# Altium schematic sheet Z80 Card for Commodore C64.SchDoc("Z80 Card for Commodore C64")
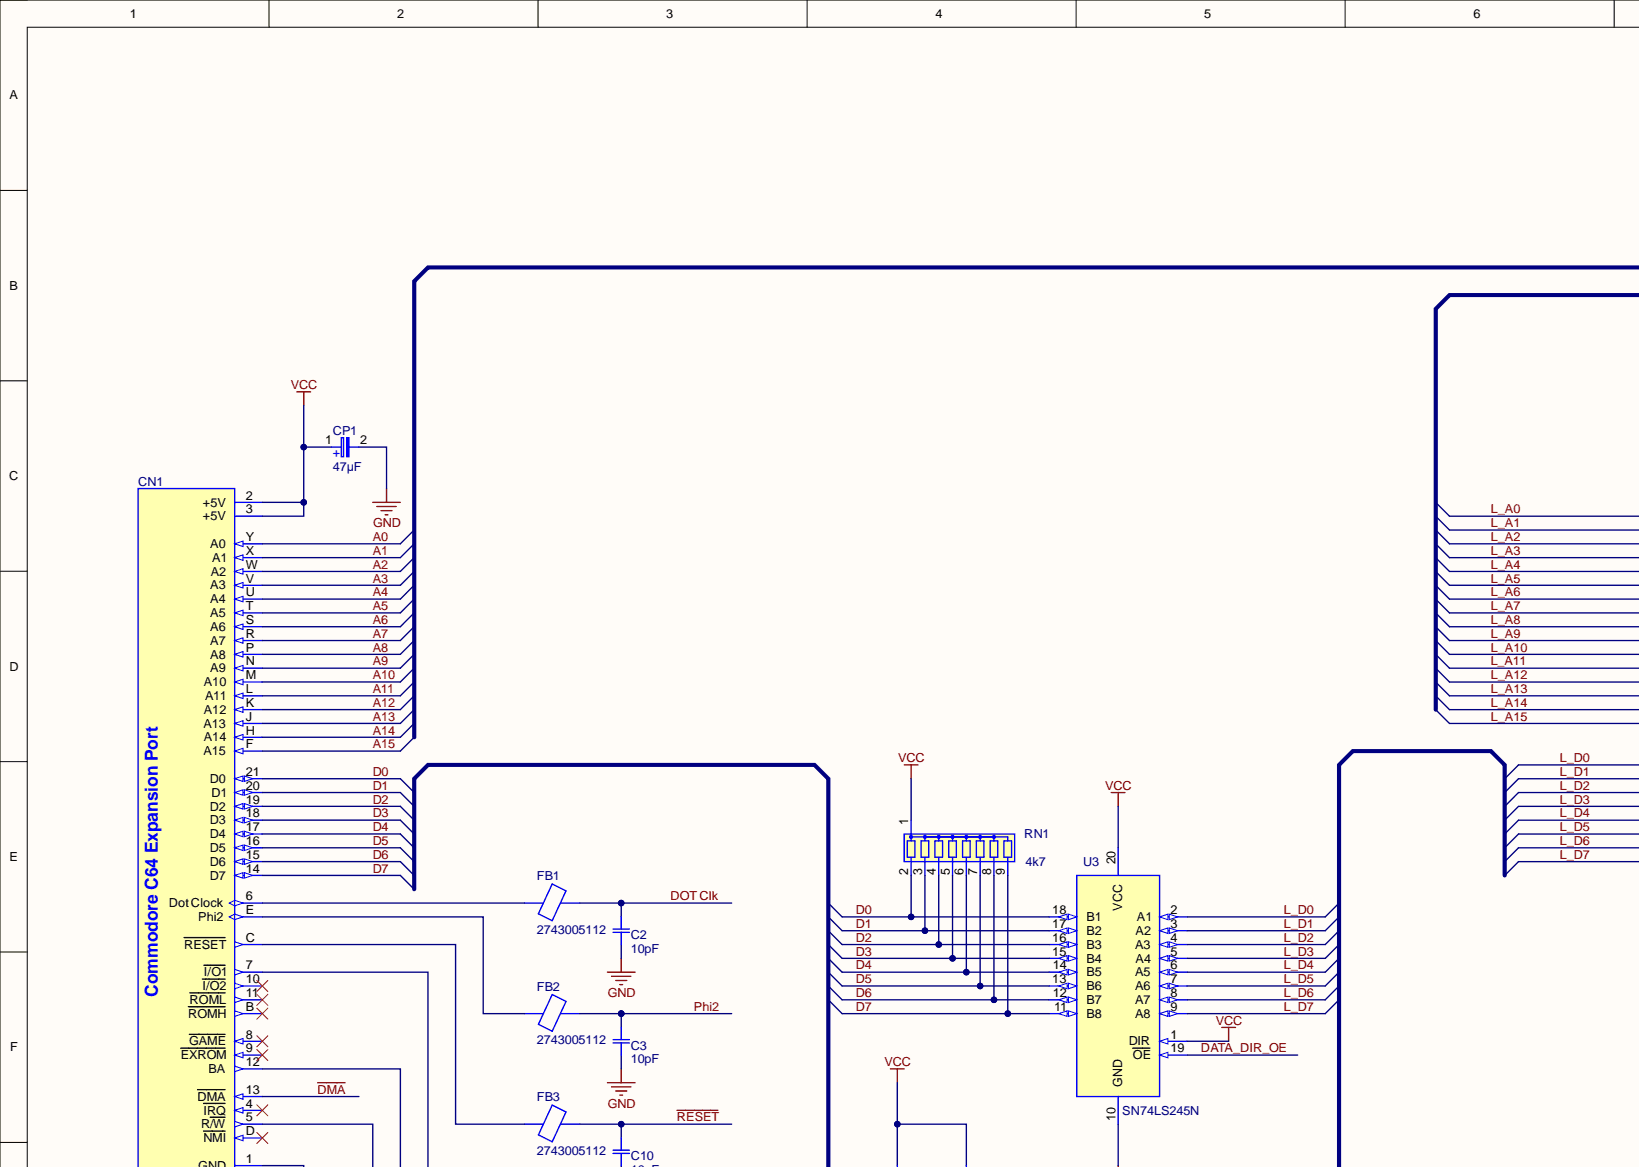
[diagram p0: Z80 Card for Commodore C64.SchDoc, top left]
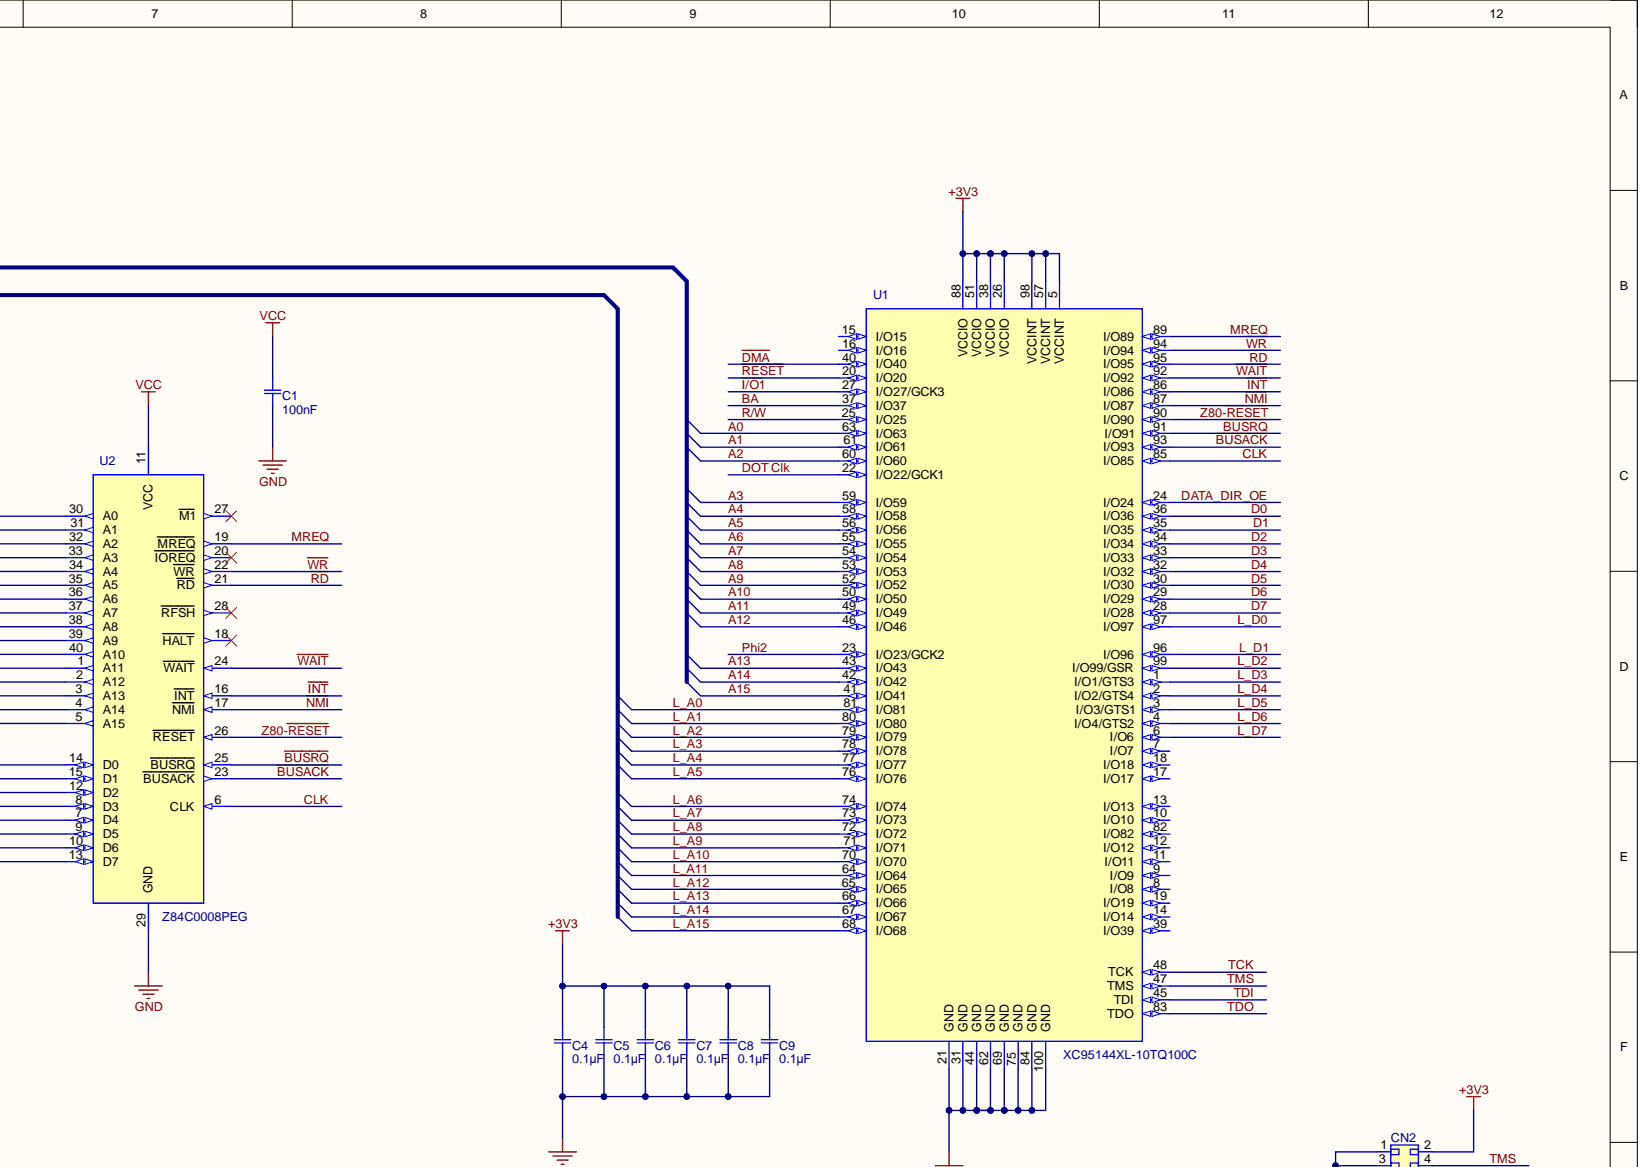
[diagram p1: Z80 Card for Commodore C64.SchDoc, top right]
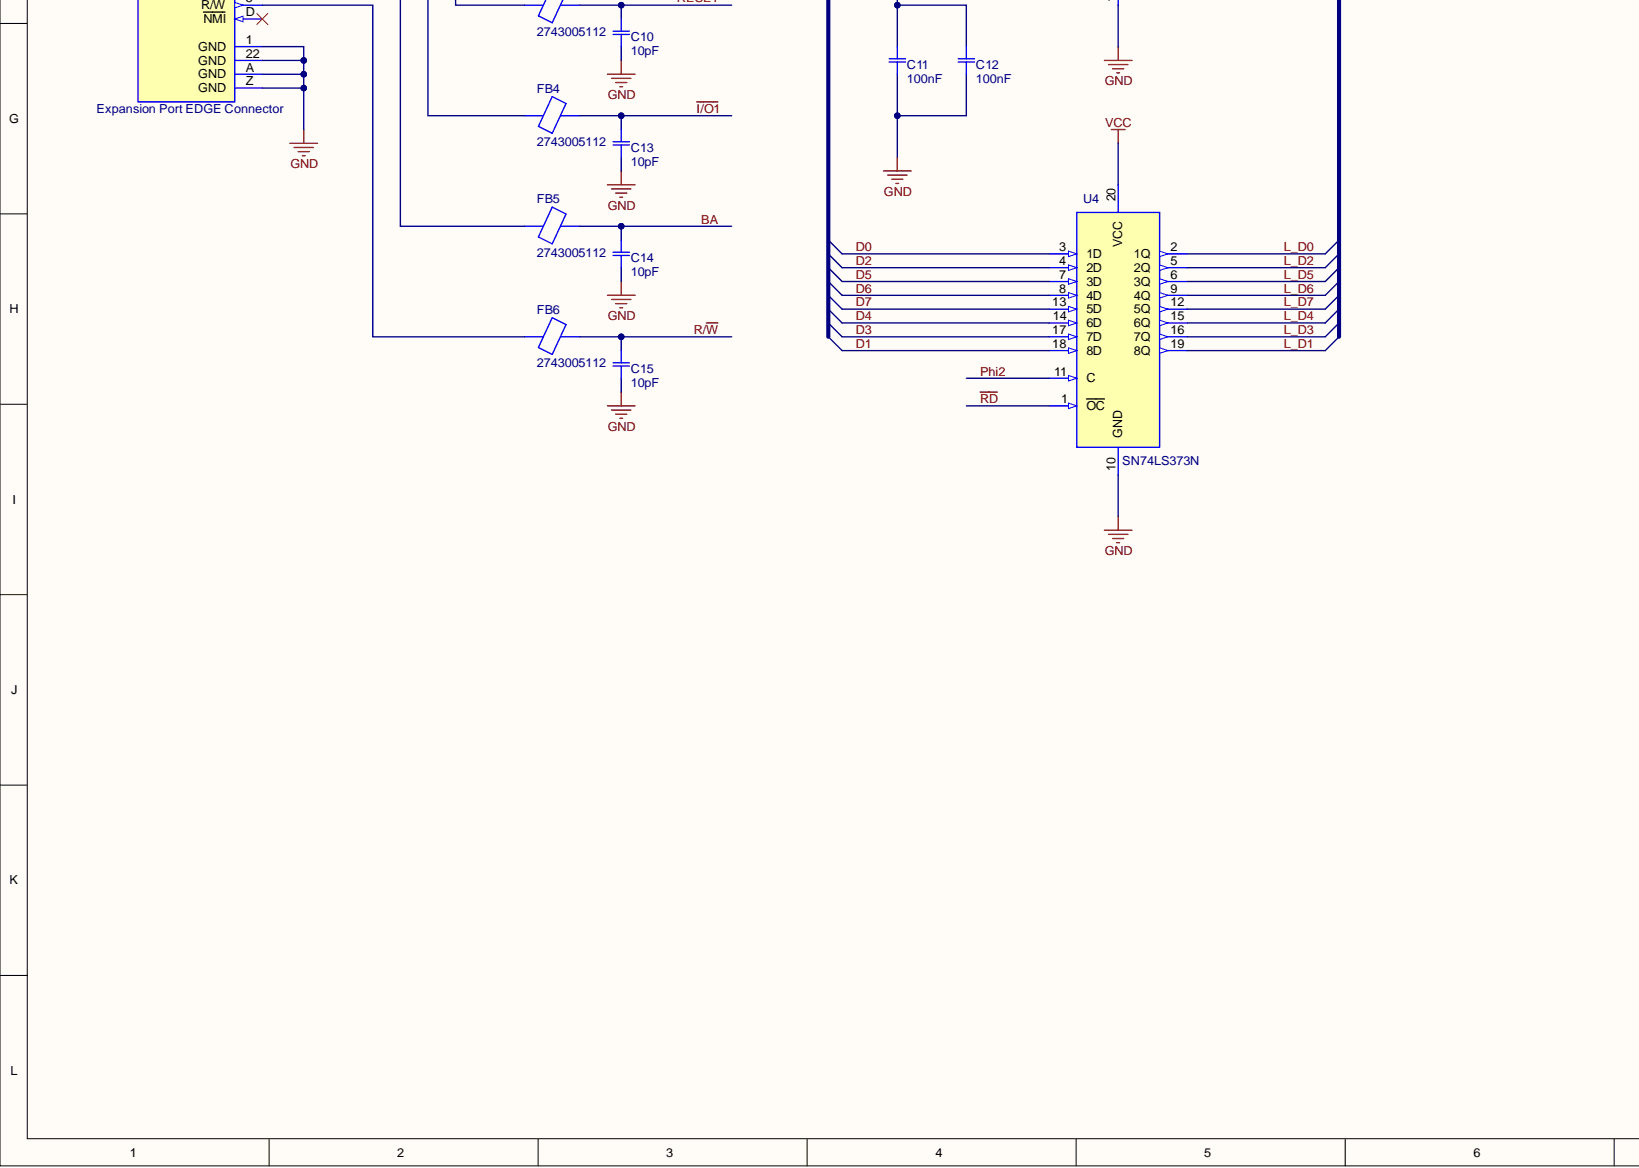
[diagram p2: Z80 Card for Commodore C64.SchDoc, bottom left]
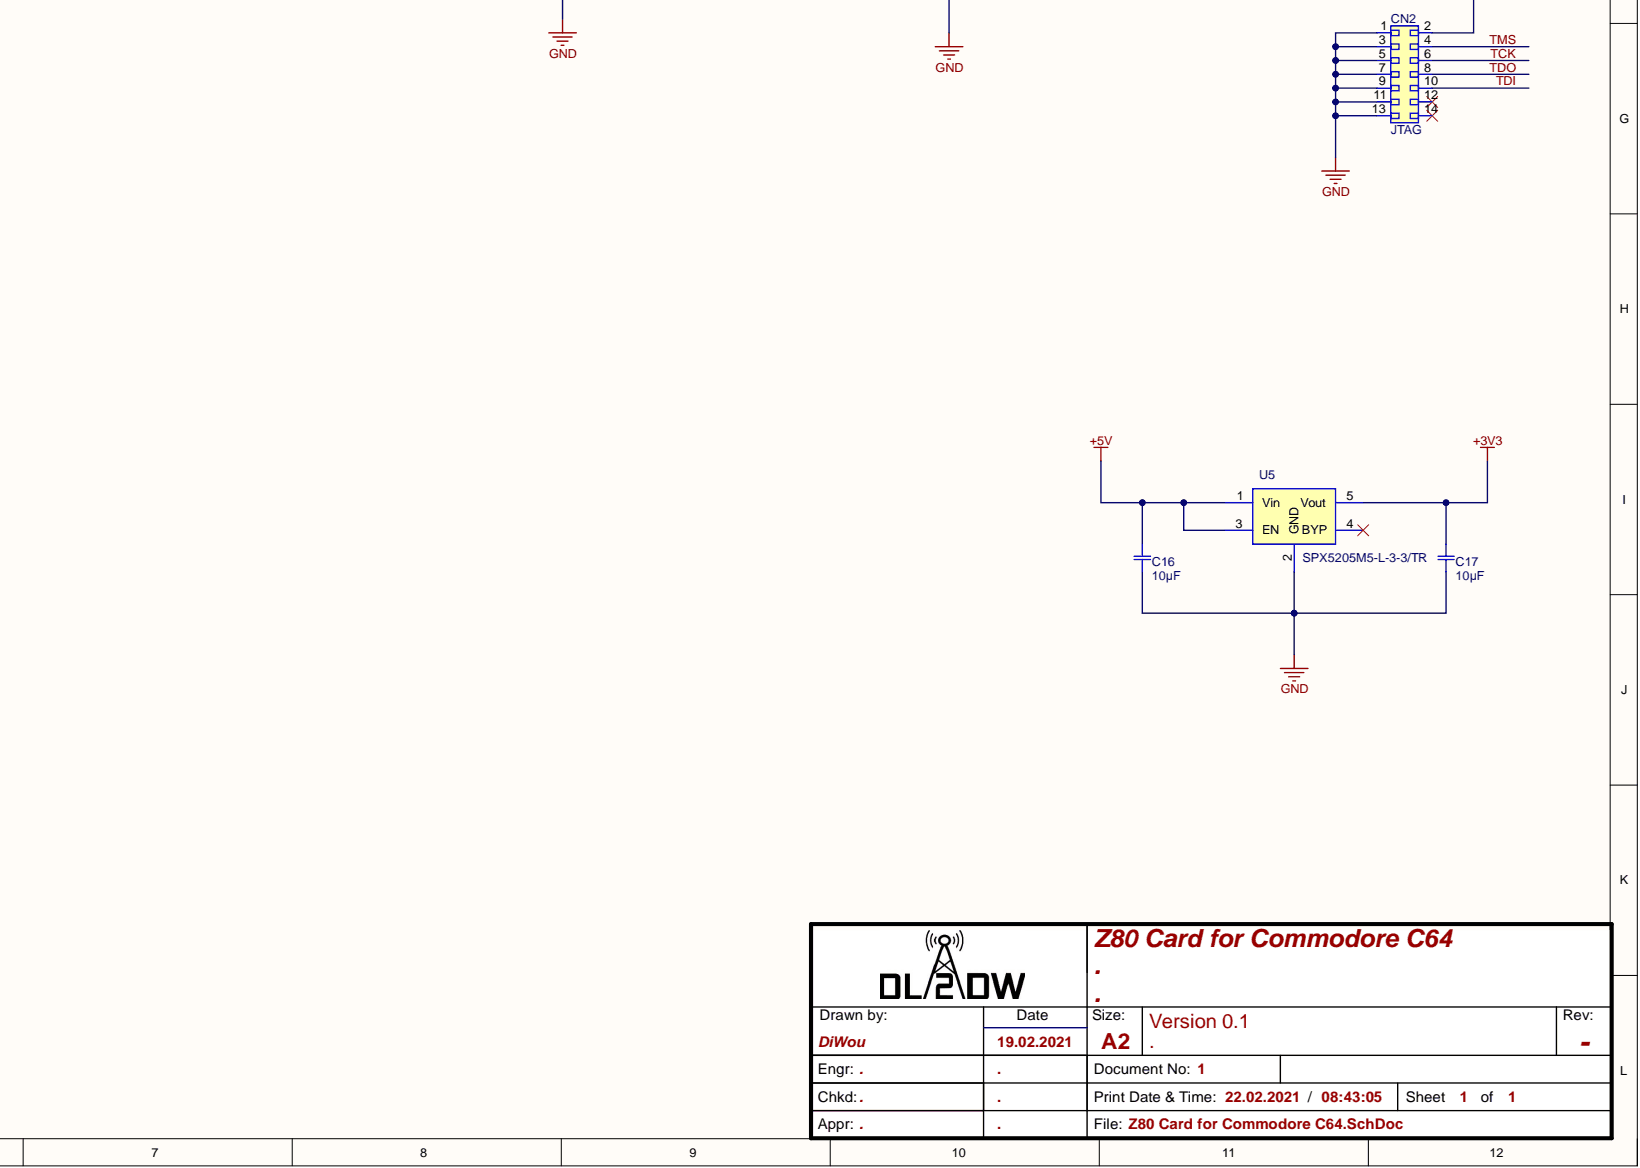
[diagram p3: Z80 Card for Commodore C64.SchDoc, bottom right]

## Parts
C1  100nF  [CAP Disc 100nF P2.54mm D5.5mm T3mm]
C2  10pF  [CAP CER 10PF 25V C0G-NP0 RADIAL Kemet C315C100J3G5TA]
C3  10pF  [CAP CER 10PF 25V C0G-NP0 RADIAL Kemet C315C100J3G5TA]
C4  0.1µF  [CAP 100nF SMD 0603 C0603C104J3RACTU]
C5  0.1µF  [CAP 100nF SMD 0603 C0603C104J3RACTU]
C6  0.1µF  [CAP 100nF SMD 0603 C0603C104J3RACTU]
C7  0.1µF  [CAP 100nF SMD 0603 C0603C104J3RACTU]
C8  0.1µF  [CAP 100nF SMD 0603 C0603C104J3RACTU]
C9  0.1µF  [CAP 100nF SMD 0603 C0603C104J3RACTU]
C10  10pF  [CAP CER 10PF 25V C0G-NP0 RADIAL Kemet C315C100J3G5TA]
C11  100nF  [CAP Disc 100nF P2.54mm D5.5mm T3mm]
C12  100nF  [CAP Disc 100nF P2.54mm D5.5mm T3mm]
C13  10pF  [CAP CER 10PF 25V C0G-NP0 RADIAL Kemet C315C100J3G5TA]
C14  10pF  [CAP CER 10PF 25V C0G-NP0 RADIAL Kemet C315C100J3G5TA]
C15  10pF  [CAP CER 10PF 25V C0G-NP0 RADIAL Kemet C315C100J3G5TA]
C16  10µF  [Kemet C0805CxxxK8RACTU Series H=1.4mm]
C17  10µF  [Kemet C0805CxxxK8RACTU Series H=1.4mm]
CN1  Expansion Port EDGE Connector  [C64 Expansion Port EDGE Connector]  (no BOM)
CN2  JTAG  [MPE 369-1-014 Buchsenleisten MicroMatch 1,27 mm,2X10,gerade]
CP1  47µF  [CAP 47µF16V D6mm H6.3mm P2.5mm]
FB1  2743005112  [Ferrite Bead Fair Rite 2743005112]
FB2  2743005112  [Ferrite Bead Fair Rite 2743005112]
FB3  2743005112  [Ferrite Bead Fair Rite 2743005112]
FB4  2743005112  [Ferrite Bead Fair Rite 2743005112]
FB5  2743005112  [Ferrite Bead Fair Rite 2743005112]
FB6  2743005112  [Ferrite Bead Fair Rite 2743005112]
RN1  4k7  [4k7 x8 Resistor Array SIL Royal 9A472G]
U1  XC95144XL-10TQ100C  [TQFP-100 P0.5mm XC95144XL-7TQ100C]
U2  Z84C0008PEG  [Zilog Z80 CPU with Socket]
U3  SN74LS245N  [SN74LS245N with Socket]
U4  SN74LS373N  [SN74LS373N with Socket]
U5  SPX5205M5-L-3-3/TR  [SOT-23-5]

## Nets
+3V3: C4.2 C5.2 C6.2 C7.2 C8.2 C9.2 C17.2 CN2.2 U1.5(VCCINT) U1.26(VCCIO) U1.38(VCCIO) U1.51(VCCIO) U1.57(VCCINT) U1.88(VCCIO) U1.98(VCCINT) U5.5(Vout)
+5V: C16.2 U5.1(Vin) U5.3(EN)
GND: C1.1 C2.1 C3.1 C4.1 C5.1 C6.1 C7.1 C8.1 C9.1 C10.1 C11.1 C12.1 C13.1 C14.1 C15.1 C16.1 C17.1 CN1.1(GND) CN1.22(GND) CN1.A(GND) CN1.Z(GND) CN2.1 CN2.3 CN2.5 CN2.7 CN2.9 CN2.11 CN2.13 CP1.2 U1.21(GND) U1.31(GND) U1.44(GND) U1.62(GND) U1.69(GND) U1.75(GND) U1.84(GND) U1.100(GND) U2.29(GND) U3.10(GND) U4.10(GND) U5.2(GND)
VCC: C1.2 C11.2 C12.2 CN1.2(+5V) CN1.3(+5V) CP1.1 RN1.1 U2.11(VCC) U3.1(DIR) U3.20(VCC) U4.20(VCC)
A0: CN1.Y(A0) U1.63(I/O63)
A1: CN1.X(A1) U1.61(I/O61)
A2: CN1.W(A2) U1.60(I/O60)
A3: CN1.V(A3) U1.59(I/O59)
A4: CN1.U(A4) U1.58(I/O58)
A5: CN1.T(A5) U1.56(I/O56)
A6: CN1.S(A6) U1.55(I/O55)
A7: CN1.R(A7) U1.54(I/O54)
A8: CN1.P(A8) U1.53(I/O53)
A9: CN1.N(A9) U1.52(I/O52)
A10: CN1.M(A10) U1.50(I/O50)
A11: CN1.L(A11) U1.49(I/O49)
A12: CN1.K(A12) U1.46(I/O46)
A13: CN1.J(A13) U1.43(I/O43)
A14: CN1.H(A14) U1.42(I/O42)
A15: CN1.F(A15) U1.41(I/O41)
~{BUSACK}: U1.93(I/O93) U2.23(BUSACK)
~{BUSRQ}: U1.91(I/O91) U2.25(BUSRQ)
BA: C14.2 FB5.2 U1.37(I/O37)
CLK: U1.85(I/O85) U2.6(CLK)
D0: CN1.21(D0) RN1.2 U1.36(I/O36) U3.18(B1) U4.3(1D)
D1: CN1.20(D1) RN1.3 U1.35(I/O35) U3.17(B2) U4.18(8D)
D2: CN1.19(D2) RN1.4 U1.34(I/O34) U3.16(B3) U4.4(2D)
D3: CN1.18(D3) RN1.5 U1.33(I/O33) U3.15(B4) U4.17(7D)
D4: CN1.17(D4) RN1.6 U1.32(I/O32) U3.14(B5) U4.14(6D)
D5: CN1.16(D5) RN1.7 U1.30(I/O30) U3.13(B6) U4.7(3D)
D6: CN1.15(D6) RN1.8 U1.29(I/O29) U3.12(B7) U4.8(4D)
D7: CN1.14(D7) RN1.9 U1.28(I/O28) U3.11(B8) U4.13(5D)
~{DMA}: CN1.13(DMA) U1.40(I/O40)
DATA_DIR_OE: U1.24(I/O24) U3.19(OE)
DOT Clk: C2.2 FB1.2 U1.22(I/O22/GCK1)
~{I/O1}: C13.2 FB4.2 U1.27(I/O27/GCK3)
~{INT}: U1.86(I/O86) U2.16(INT)
L_A0: U1.81(I/O81) U2.30(A0)
L_A1: U1.80(I/O80) U2.31(A1)
L_A2: U1.79(I/O79) U2.32(A2)
L_A3: U1.78(I/O78) U2.33(A3)
L_A4: U1.77(I/O77) U2.34(A4)
L_A5: U1.76(I/O76) U2.35(A5)
L_A6: U1.74(I/O74) U2.36(A6)
L_A7: U1.73(I/O73) U2.37(A7)
L_A8: U1.72(I/O72) U2.38(A8)
L_A9: U1.71(I/O71) U2.39(A9)
L_A10: U1.70(I/O70) U2.40(A10)
L_A11: U1.64(I/O64) U2.1(A11)
L_A12: U1.65(I/O65) U2.2(A12)
L_A13: U1.66(I/O66) U2.3(A13)
L_A14: U1.67(I/O67) U2.4(A14)
L_A15: U1.68(I/O68) U2.5(A15)
L_D0: U1.97(I/O97) U2.14(D0) U3.2(A1) U4.2(1Q)
L_D1: U1.96(I/O96) U2.15(D1) U3.3(A2) U4.19(8Q)
L_D2: U1.99(I/O99/GSR) U2.12(D2) U3.4(A3) U4.5(2Q)
L_D3: U1.1(I/O1/GTS3) U2.8(D3) U3.5(A4) U4.16(7Q)
L_D4: U1.2(I/O2/GTS4) U2.7(D4) U3.6(A5) U4.15(6Q)
L_D5: U1.3(I/O3/GTS1) U2.9(D5) U3.7(A6) U4.6(3Q)
L_D6: U1.4(I/O4/GTS2) U2.10(D6) U3.8(A7) U4.9(4Q)
L_D7: U1.6(I/O6) U2.13(D7) U3.9(A8) U4.12(5Q)
MREQ: U1.89(I/O89) U2.19(MREQ)
~{NMI}: U1.87(I/O87) U2.17(NMI)
Phi2: C3.2 FB2.2 U1.23(I/O23/GCK2) U4.11(C)
R/~{W}: C15.2 FB6.2 U1.25(I/O25)
~{RD}: U1.95(I/O95) U2.21(RD) U4.1(OC)
~{RESET}: C10.2 FB3.2 U1.20(I/O20)
TCK: CN2.6 U1.48(TCK)
TDI: CN2.10 U1.45(TDI)
TDO: CN2.8 U1.83(TDO)
TMS: CN2.4 U1.47(TMS)
~{WAIT}: U1.92(I/O92) U2.24(WAIT)
~{WR}: U1.94(I/O94) U2.22(WR)
Z80-~{RESET}: U1.90(I/O90) U2.26(RESET)
NetCN1_5: CN1.5(R/W) FB6.1
NetCN1_6: CN1.6(Dot_Clock) FB1.1
NetCN1_7: CN1.7(I/O1) FB4.1
NetCN1_12: CN1.12(BA) FB5.1
NetCN1_C: CN1.C(RESET) FB3.1
NetCN1_E: CN1.E(Phi2) FB2.1

Not connected: CN1 4(IRQ) 8(GAME) 9(EXROM) 10(I/O2) 11(ROML) B(ROMH) D(NMI); CN2 12 14; U1 7(I/O7) 8(I/O8) 9(I/O9) 10(I/O10) 11(I/O11) 12(I/O12) 13(I/O13) 14(I/O14) 15(I/O15) 16(I/O16) 17(I/O17) 18(I/O18) 19(I/O19) 39(I/O39) 82(I/O82); U2 18(HALT) 20(IOREQ) 27(M1) 28(RFSH); U5 4(BYP)

## Library data of the parts
C1 C11 C12 = CMP-Capacitors-Ceramic_Capacitors-00007-4: Ceramic Disc Capacitors 100nF -20%~+80% 50V Through Hole,P=2.54mm RoHS; Component Kind: Standard; ComponentLink1URL: https://lcsc.com/product-detail/Others_Dersonic-CC1H104ZA1PD3F5O3000_C353909.html; Library Name: PCB-Tech Cloud
C2 C3 C10 C13-C15 = CMP-Capacitors-Ceramic_Capacitors-00049-1: CAP CER 10PF 25V C0G/NP0 RADIAL; Component Kind: Standard; Library Name: PCB-Tech Cloud
C4-C9 = CMP-Capacitors-Ceramic_Capacitors-00012-1: KEMET         C0603C104J3RACTU             SMD Multilayer Ceramic Capacitor, 0603 [1608 Metric], 0.1 F, 25 V,  5%, X7R, C Series; Capacitance: 100nF; Voltage: 25V; Case Code (Imperial): 0603; Case Code (Metric): 1608; Case/Package: 0603; Component Kind: Standard; Contact Plating: Tin,Matte; Depth: 0.8mm; Dielectric: X7R; Dielectric Material: Ceramic; Height: 0.8mm; Lead Pitch: 0.7mm; Length: 1.6mm; Library Name: PCB-Tech Cloud; Max Operating Temperature: 125°C; Min Operating Temperature: -55°C; Mount: Surface Mount,PCB; Number of Pins: 2; Package Quantity: 4000; Packaging: Tape and Reel; Radiation Hardening: No; REACH SVHC: No SVHC; Termination: SMD/SMT; Thickness: 0.034inch; Tolerance: 5%; Voltage Rating: 25V; Voltage Rating (DC): 25V; Width: 0.031inch
C16 C17 = CMP-Capacitors-Ceramic_Capacitors-00016-2: KEMET - C0805C106K8RACTU - CAP, 10µF, 10V, 10%, X7R, 0805; Capacitance: 10uF; Dissipation Factor: 7.5%; Case Code (Imperial): 0805; Case Code (Metric): 2012; Case/Package: 0805; Component Kind: Standard; Depth: 1.25mm; Dielectric: X7R; Dielectric Material: Ceramic; Height: 1.25mm; Length: 2mm; Library Name: PCB-Tech Cloud; Max Operating Temperature: 125°C; Min Operating Temperature: -55°C; Mount: Surface Mount,PCB; Package Quantity: 1; Packaging: Tape and Reel; REACH SVHC: No SVHC; Termination: SMD/SMT; Thickness: 0.055inch; Tolerance: 10%; Voltage: 10V; Voltage Rating: 10V; Voltage Rating (DC): 10V; Width: 1.2mm
CN1 = CMP-Commodore-00008-4: Expansion Port EDGE Connector for Interface Cards; Library Name: PCB-Tech Cloud
CN2 = CMP-Connectors-IDC_Connectors-00033-4: Grid pitch: 1.27 mm BL2/15-0400GTT-014 RU MPE Garry Conten; Pitch: 1.27mm; Component Kind: Standard; Contact Material: Bronze,Tin; Height: 3.95mm; Insulation Material: Thermoplastic; Length: 19.7mm; Library Name: PCB-Tech Cloud; Width: 5mm
CP1 = CMP-Capacitors-Electrolyt_Capacitors00000-5: Aluminum Electrolytic Capacitors - Leaded 47uF 105c; Impedance: 1.3R; Life (Hours): 1000Hour; Ripple Current: 115mA; Tolerance: 20%; Voltage Rating: 16V; Capacitance: 47uF; Case/Package: Radial; Component Kind: Standard; Height - Seated (Max): 0.236inch; Lead Pitch: 0.098inch; Length: 5mm; Library Name: PCB-Tech Cloud; Max Operating Temperature: 105°C; Min Operating Temperature: -55°C; Mount: Through Hole; Package Quantity: 2000; Packaging: Tape and Reel; Termination: Radial; Voltage Rating (DC): 16V
FB1-FB6 = CMP-Inductors-00000-10: Ferrite Beads Axial 91Ohm 100MHz T/R; Impedance: 91R; Shielding: Unshielded; Case/Package: Axial; Component Kind: Standard; Diameter: 3.5mm; Length: 6mm; Library Name: PCB-Tech Cloud; Max Operating Temperature: 125°C; Min Operating Temperature: -55°C; Package Quantity: 4500; Packaging: Tape and Reel; Termination: Axial; Test Frequency: 100MHz
RN1 = CMP-Resistors-Resistor_Array00016-1: Resistor Networks & Arrays 9pin 4.7Kohms 2% Bussed; Number of Contacts: 9; Number of Elements: 10; Number of Resistors: 8; Power Per Element: 200mW; Power Rating: 1.12W; Resistance: 4.7kR; Temperature Coefficient: 100ppm/°C; Voltage Rating: 100V; Case/Package: SIP; Circuit Type: Bussed; Component Kind: Standard; Contact Plating: Tin,Lead; Depth: 2.49mm; Height: 0.195inch; Lead Free: Contains Lead; Lead Pitch: 2.54mm; Length: 22.61mm; Library Name: PCB-Tech Cloud; Max Operating Temperature: 125°C; Military Standard: Not; Min Operating Temperature: -55°C; Mount: Through Hole; Number of Pins: 9; Number of Terminals: 10; Number of Terminals/Pins: 9; Package Quantity: 1000; Packaging: Bulk; Radiation Hardening: No; REACH SVHC: Unknown; Resistance Tolerance: 2%; RoHS Compliant: No; Series: CSC; Technology: THICK FILM; Terminal Pitch: 2.54mm; Termination: Pin; Thickness: 2.49mm; Tolerance: 2%; Voltage Rating (DC): 100V; Width: 0.098inch; Working Voltage: 100V
U1 = CMP-ICs-CPLD_FPGA-00000-1: IC CPLD 144MC 7.5NS 100TQFP; Max Frequency: 125MHz; Max Supply Voltage: 3.6V; Memory Type: FLASH; Min Supply Voltage: 3V; Number of Gates: 3200; Number of I/Os: 81; Number of Logic Blocks (LABs): 8; Number of Macrocells: 144; Operating Supply Voltage: 3.3V; Speed Grade: 7; Case/Package: LQFP; Component Kind: Standard; Contact Plating: Tin,Lead; Lead Free: Contains Lead; Library Name: PCB-Tech Cloud; Max Operating Temperature: 70°C; Min Operating Temperature: 0°C; Mount: Surface Mount; Number of Logic Elements/Cells: 8; Number of Pins: 100; Package Quantity: 90; Packaging: Tray; Propagation Delay: 7.5ns; Turn-On Delay Time: 7.5ns
U2 = CMP-ICs-00009-1: IC MPU Z80 8MHZ 40DIP; Core Architecture: Z8; Data Bus Width: 8b; Max Supply Voltage: 5V; Memory Size: 64KB; Memory Type: ROMless; Min Supply Voltage: 4.5V; Number of I/Os: 24; Case/Package: DIP; Component Kind: Standard; Frequency: 8MHz; Height: 4.19mm; Lead Free: Lead Free; Length: 62.74mm; Library Name: PCB-Tech Cloud; Max Frequency: 8MHz; Max Operating Temperature: 100°C; Min Operating Temperature: -40°C; Mount: Through Hole; Number of Pins: 40; Package Quantity: 10; Packaging: Rail/Tube; Radiation Hardening: No; REACH SVHC: Unknown; Voltage: 5V; Width: 14.22mm
U3 = CMP-ICs-74xx-series-00046-2: IC TXRX NON-INVERT 5.25V 20DIP; Direction: Bidirectional; High Level Output Current: -15mA; Logic Function: Transceiver,Inverting; Low Level Output Current: 24mA; Min Supply Voltage: 4.75V; Number of Bits: 8; Number of Elements: 1; Operating Supply Voltage: 5V; Polarity: Non-Inverting; Propagation Delay: 12ns; Quiescent Current: 62mA; Case/Package: PDIP; Component Kind: Standard; Contact Plating: Gold; Height: 4.57mm; Lead Free: Lead Free; Length: 24.33mm; Library Name: PCB-Tech Cloud; Max Operating Temperature: 70°C; Max Supply Voltage: 5.25V; Min Operating Temperature: 0°C; Mount: Through Hole; Number of Bits per Element: 8; Number of Channels: 8; Number of Circuits: 8; Number of Outputs: 8; Number of Pins: 20; Number of Transceivers: 8; Package Quantity: 20; Packaging: Rail/Tube; Radiation Hardening: No; REACH SVHC: No SVHC; Termination: Through Hole; Turn-On Delay Time: 12ns; Weight: 0.042297oz; Width: 6.35mm
U4 = CMP-ICs-74xx-series-00062-1: Latch Transparent 3-ST 8-CH D-Type 20-Pin PDIP Tube; High Level Output Current: -2.6mA; Independent Circuits: 1; Logic Function: D-Type,Latch,Flip-Flop; Low Level Output Current: 24mA; Max Supply Voltage: 5.25V; Min Supply Voltage: 4.75V; Number of Bits: 8; Number of Input Lines: 3; Operating Supply Current: 40mA; Operating Supply Voltage: 5V; Polarity: Non-Inverting; Propagation Delay: 18ns; Turn-On Delay Time: 12ns; Case/Package: PDIP; Component Kind: Standard; Contact Plating: Gold; Height: 4.57mm; Lead Free: Lead Free; Length: 24.33mm; Library Name: PCB-Tech Cloud; Max Operating Temperature: 70°C; Min Operating Temperature: 0°C; Mount: Through Hole; Number of Channels: 8; Number of Circuits: 8; Number of Elements: 1; Number of Output Lines: 1; Number of Outputs: 8; Number of Pins: 20; Output Current: 24mA; Package Quantity: 20; Packaging: Rail/Tube; Quiescent Current: 24mA; Radiation Hardening: No; REACH SVHC: No SVHC; Weight: 0.042297oz; Width: 6.35mm
U5 = CMP-ICs-Power_Supply-00002-2: LDO Regulator Pos 3.3V 0.15A 5-Pin SOT-23 T/R; Accuracy: 1%; Dropout Voltage: 210mV; Max Input Voltage: 16V; Max Output Current: 150mA; Min Input Voltage: 2.5V; Number of Outputs: 1; Output Type: Fixed; Polarity: Positive; Quiescent Current: 1uA; Case/Package: SOT-23; Component Kind: Standard; Height: 1.15mm; Lead Free: Lead Free; Length: 2.9mm; Library Name: PCB-Tech Cloud; Max Operating Temperature: 125°C; Min Operating Temperature: -40°C; Min Output Voltage: 3.3V; Mount: Surface Mount; Number of Pins: 5; Number of Regulators: 1; Output Current: 150mA; Output Voltage: 3.3V; Packaging: Tape and Reel; Radiation Hardening: No; Width: 1.6mm
